# Altium schematic sheet 电源.SchDoc
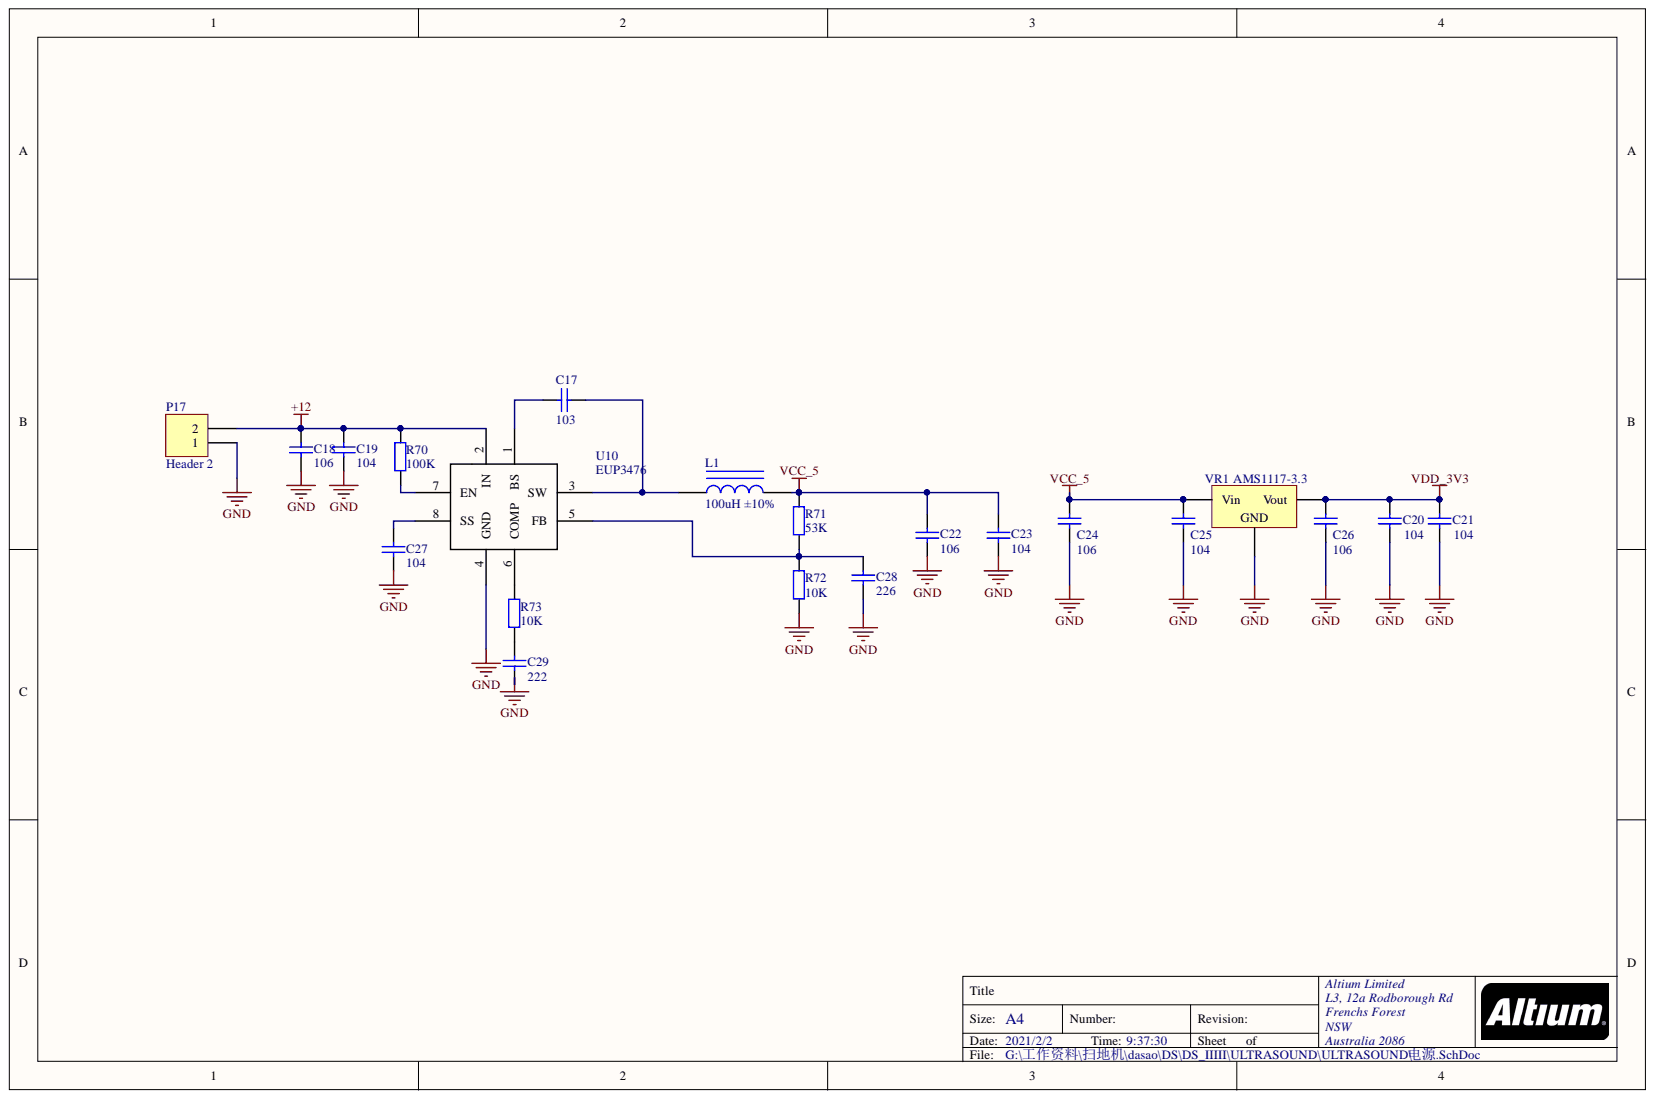
[diagram p0: 电源.SchDoc]

## Parts
C17  103  [0603_C]
C18  106  [1206_C]
C19  104  [0603_C]
C20  104  [0603_C]
C21  104  [0603_C]
C22  106  [1206_C]
C23  104  [0603_C]
C24  106  [1206_C]
C25  104  [0603_C]
C26  106  [1206_C]
C27  104  [0603_C]
C28  226  [0603_C]
C29  222  [0603_C]
L1  100uH ±10%  [IN]
P17  Header 2  [CON1.5_2pin]
R70  100K  [0603_R]
R71  53K  [0603_R]
R72  10K  [0603_R]
R73  10K  [0603_R]
U10  EUP3476  [SOP-8_150mil]
VR1  AMS1117-3.3  [SOT-223]

## Nets
+12: C18.2 C19.1 P17.2 R70.2 U10.2(IN)
GND: C18.1 C19.2 C20.2 C21.2 C22.1 C23.2 C24.2 C25.2 C26.2 C27.2 C28.1 C29.2 P17.1 R72.1 U10.4(GND) VR1.1
VCC_5: C22.2 C23.1 C24.1 C25.1 L1.2 R71.2 VR1.3
VDD_3V3: C20.1 C21.1 C26.1 VR1.2
NetC17_1: C17.1 U10.1(BS)
NetC17_2: C17.2 L1.1 U10.3(SW)
NetC27_1: C27.1 U10.8(SS)
NetC28_2: C28.2 R71.1 R72.2 U10.5(FB)
NetC29_1: C29.1 R73.1
NetR70_1: R70.1 U10.7(EN)
NetR73_2: R73.2 U10.6(COMP)

## Library data of the parts
C17 = 0603 10nF (103) 10% 50V: 贴片电容; Component Kind: Standard; ComponentLink1Description: 供应商链接; ComponentLink1URL: http://www.szlcsc.com/product/details_58143.html; Library Name: Miscellaneous Devices LC.IntLib; Package: 0603; Supplier: LC; SuppliersPartNumber: C57112
C18 C22 = 0603 10uF (106) 10% 10V: 贴片电容; Component Kind: Standard; ComponentLink1Description: 供应商链接; ComponentLink1URL: http://www.szlcsc.com/product/details_20411.html; Library Name: Miscellaneous Devices LC.IntLib; Package: 0603; Supplier: LC; SuppliersPartNumber: C19702
C19 C23 C27 = 0603 100nF (104) 10% 50V: 贴片电容; Component Kind: Standard; ComponentLink1Description: 供应商链接; ComponentLink1URL: http://www.szlcsc.com/product/details_15331.html; Library Name: Miscellaneous Devices LC.IntLib; Package: 0603; Supplier: LC; SuppliersPartNumber: C14663
C20 C21 C24-C26 = 0603 1uF (105) 10% 50V: 贴片电容; Component Kind: Standard; ComponentLink1Description: 供应商链接; ComponentLink1URL: http://www.szlcsc.com/product/details_16531.html; Library Name: Miscellaneous Devices LC.IntLib; Supplier: LC; SuppliersPartNumber: C15849
  C20 C21 C25: Package: 0603
  C24 C26: Package: 1206
C28 = 0603 22uF (226) 20% 6.3V: 贴片电容; Component Kind: Standard; ComponentLink1Description: 供应商链接; ComponentLink1URL: http://www.szlcsc.com/product/details_60514.html; Library Name: Miscellaneous Devices LC.IntLib; Package: 0603; Supplier: LC; SuppliersPartNumber: C59461
C29 = 0603 2.2nF (222) 10% 50V: 贴片电容; Component Kind: Standard; ComponentLink1Description: 供应商链接; ComponentLink1URL: http://www.szlcsc.com/product/details_1956.html; Library Name: Miscellaneous Devices LC.IntLib; Package: 0603; Supplier: LC; SuppliersPartNumber: C1604
L1 = 0805 100uH 10%: 贴片电感; Component Kind: Standard; ComponentLink1Description: 供应商链接; ComponentLink1URL: http://www.szlcsc.com/product/details_69137.html; Library Name: Miscellaneous Devices LC.IntLib; Package: 0805; Supplier: LC; SuppliersPartNumber: C68035
P17 = Header 2: Header, 2-Pin; Component Kind: Standard; LatestRevisionDate: 17-Jul-2002; LatestRevisionNote: Re-released for DXP Platform.; Library Name: Miscellaneous Connectors.IntLib; Signal Integrity: Connector; Publisher: Altium Limited
R70 = 0603 100KΩ (1003) 1%: 贴片电阻; Component Kind: Standard; ComponentLink1Description: 供应商链接; ComponentLink1URL: http://www.szlcsc.com/product/details_26546.html; Library Name: Miscellaneous Devices LC.IntLib; Package: 0603; Supplier: LC; SuppliersPartNumber: C25803
R71 = 0603 33KΩ (3302) 1%: 贴片电阻; Component Kind: Standard; ComponentLink1Description: 供应商链接; ComponentLink1URL: http://www.szlcsc.com/product/details_4623.html; Library Name: Miscellaneous Devices LC.IntLib; Package: 0603; Supplier: LC; SuppliersPartNumber: C4216
R72 R73 = 0603 10KΩ (1002) 1%: 贴片电阻; Component Kind: Standard; ComponentLink1Description: 供应商链接; ComponentLink1URL: http://www.szlcsc.com/product/details_26547.html; Library Name: Miscellaneous Devices LC.IntLib; Package: 0603; Supplier: LC; SuppliersPartNumber: C25804
VR1 = SOT-223 AMS1117-3.3: 低压差线性稳压(LDO); Component Kind: Standard; ComponentLink1Description: 供应商链接; ComponentLink1URL: http://www.szlcsc.com/product/details_6652.html; Library Name: Miscellaneous Devices LC.IntLib; Package: SOT-223; Supplier: LC; SuppliersPartNumber: C6186
U10 = EUP3476: Component Kind: Standard; Library Name: Schlib1.SchLib
